# Altium schematic sheet SoM.SchDoc(SoM)
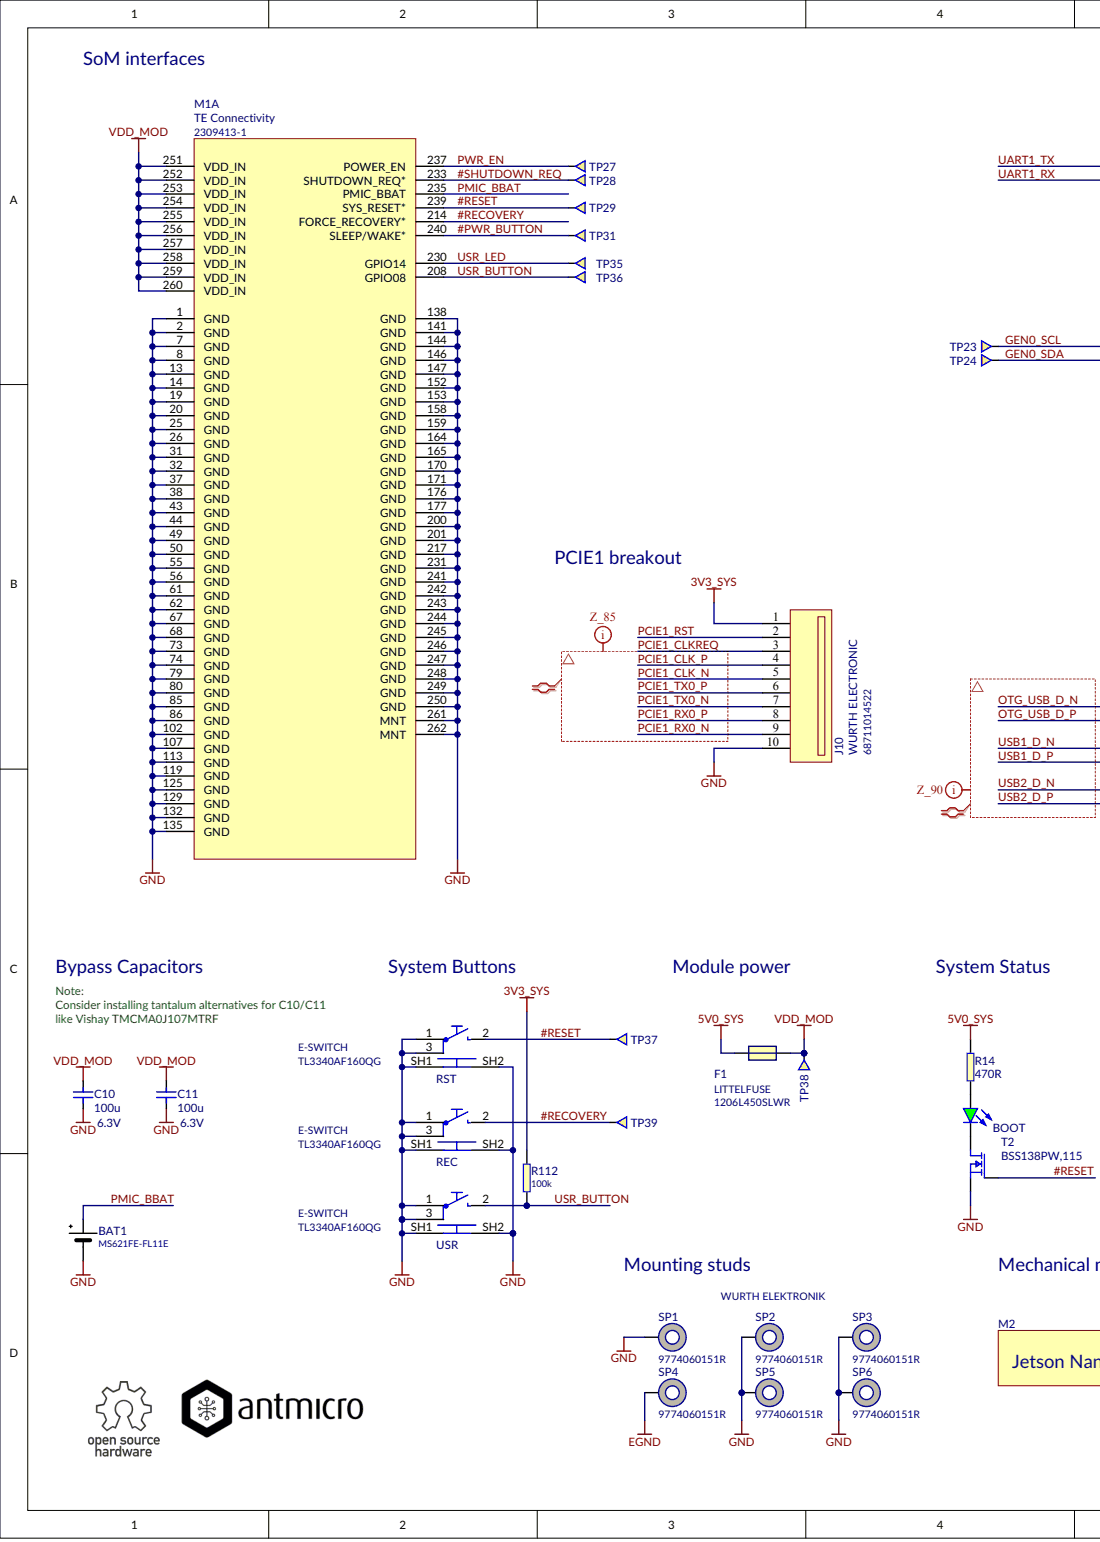
[diagram p0: SoM.SchDoc, left]
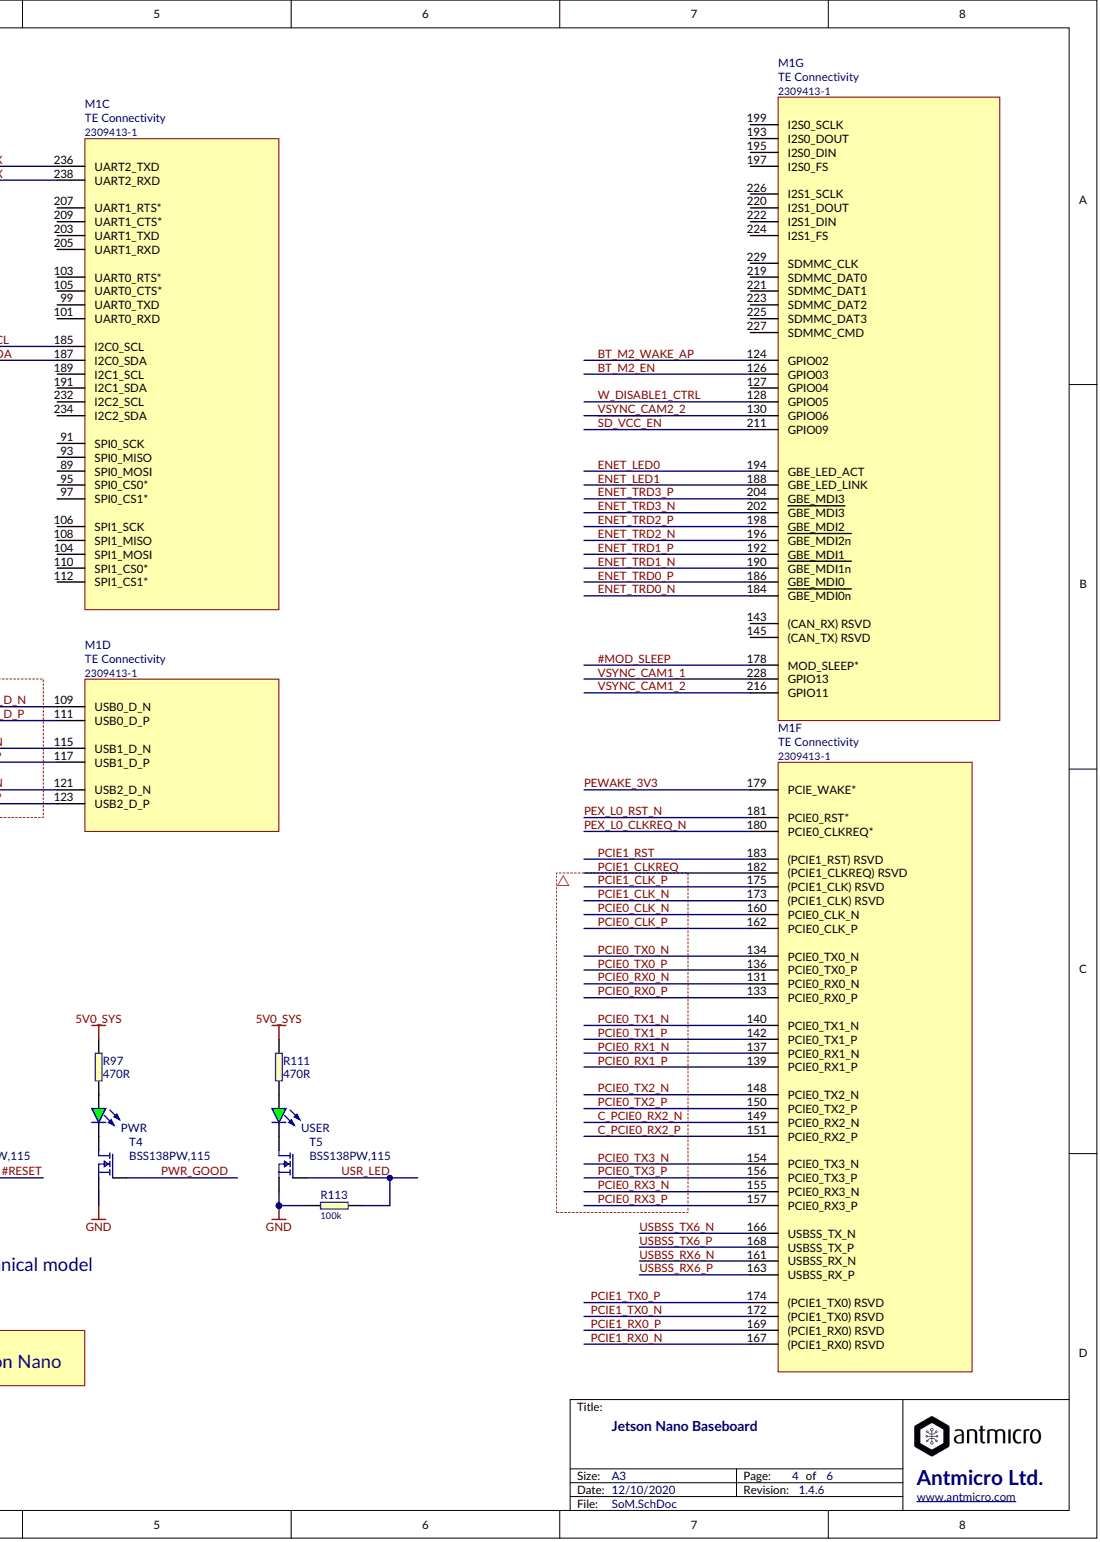
[diagram p1: SoM.SchDoc, right]

## Parts
BAT1  MS621FE-FL11E
BOOT  APT1608SGC  (value not drawn)
C10  Voltage: 6.3V  (hidden comment: 100u)
C11  Voltage: 6.3V  (hidden comment: 100u)
F1  Manufacturer: LITTELFUSE  (hidden comment: Value)
J10  Manufacturer: WURTH ELECTRONIC  (hidden comment: 68711014522)
M1  Manufacturer: TE Connectivity  (hidden comment: 2309413-1)  (parts A,C,D,F,G)
M2  =Value
PWR  APT1608SGC  (value not drawn)
R14  470R
R97  470R
R111  470R
R112  100k
R113  100k
REC  TL3340AF160QG
RST  TL3340AF160QG
SP1  MPN: 9774060151R  (hidden comment: 9774060151)
SP2  Manufacturer: WURTH ELEKTRONIK  MPN: 9774060151R  (hidden comment: 9774060151)
SP3  MPN: 9774060151R  (hidden comment: 9774060151)
SP4  MPN: 9774060151R  (hidden comment: 9774060151)
SP5  MPN: 9774060151R  (hidden comment: 9774060151)
SP6  MPN: 9774060151R  (hidden comment: 9774060151)
T2  BSS138PW,115
T4  BSS138PW,115
T5  BSS138PW,115
TP23  Testpad  (value not drawn)
TP24  Testpad  (value not drawn)
TP27  Testpad  (value not drawn)
TP28  Testpad  (value not drawn)
TP29  Testpad  (value not drawn)
TP31  Testpad  (value not drawn)
TP35  Testpad  (value not drawn)
TP36  Testpad  (value not drawn)
TP37  Testpad  (value not drawn)
TP38  Testpad  (value not drawn)
TP39  Testpad  (value not drawn)
USER  APT1608SGC  (value not drawn)
USR  TL3340AF160QG

## Nets
3V3_SYS: J10.1 R112.2
5V0_SYS: F1.1 R14.2 R97.2 R111.2
EGND: SP4.1
GND: BAT1.- C10.1 C11.1 J10.10 M1.1(GND) M1.2(GND) M1.7(GND) M1.8(GND) M1.13(GND) M1.14(GND) M1.19(GND) M1.20(GND) M1.25(GND) M1.26(GND) M1.31(GND) M1.32(GND) M1.37(GND) M1.38(GND) M1.43(GND) M1.44(GND) M1.49(GND) M1.50(GND) M1.55(GND) M1.56(GND) M1.61(GND) M1.62(GND) M1.67(GND) M1.68(GND) M1.73(GND) M1.74(GND) M1.79(GND) M1.80(GND) M1.85(GND) M1.86(GND) M1.102(GND) M1.107(GND) M1.113(GND) M1.119(GND) M1.125(GND) M1.129(GND) M1.132(GND) M1.135(GND) M1.138(GND) M1.141(GND) M1.144(GND) M1.146(GND) M1.147(GND) M1.152(GND) M1.153(GND) M1.158(GND) M1.159(GND) M1.164(GND) M1.165(GND) M1.170(GND) M1.171(GND) M1.176(GND) M1.177(GND) M1.200(GND) M1.201(GND) M1.217(GND) M1.231(GND) M1.241(GND) M1.242(GND) M1.243(GND) M1.244(GND) M1.245(GND) M1.246(GND) M1.247(GND) M1.248(GND) M1.249(GND) M1.250(GND) M1.261(MNT) M1.262(MNT) R113.2 REC.1 REC.3 REC.SH1 REC.SH2 RST.1 RST.3 RST.SH1 RST.SH2 SP1.1 SP2.1 SP3.1 SP5.1 SP6.1 T2.2 T4.2 T5.2 USR.1 USR.3 USR.SH1 USR.SH2
VDD_MOD: C10.2 C11.2 F1.2 M1.251(VDD_IN) M1.252(VDD_IN) M1.253(VDD_IN) M1.254(VDD_IN) M1.255(VDD_IN) M1.256(VDD_IN) M1.257(VDD_IN) M1.258(VDD_IN) M1.259(VDD_IN) M1.260(VDD_IN) TP38.1
#MOD_SLEEP: M1.178(MOD_SLEEP*)
#PWR_BUTTON: M1.240(SLEEP/WAKE*) TP31.1
#RECOVERY: M1.214(FORCE_RECOVERY*) REC.2 TP39.1
#RESET: M1.239(SYS_RESET*) RST.2 T2.1 TP29.1 TP37.1
#SHUTDOWN_REQ: M1.233(SHUTDOWN_REQ*) TP28.1
~{PEWAKE_3V3}: M1.179(PCIE_WAKE*)
BT_M2_EN: M1.126(GPIO03)
BT_M2_WAKE_AP: M1.124(GPIO02)
ENET_LED0: M1.194(GBE_LED_ACT)
ENET_LED1: M1.188(GBE_LED_LINK)
ENET_TRD0_N: M1.184(GBE_MDI0n)
ENET_TRD0_P: M1.186(GBE_MDI0)
ENET_TRD1_N: M1.190(GBE_MDI1n)
ENET_TRD1_P: M1.192(GBE_MDI1)
ENET_TRD2_N: M1.196(GBE_MDI2n)
ENET_TRD2_P: M1.198(GBE_MDI2)
ENET_TRD3_N: M1.202(GBE_MDI3)
ENET_TRD3_P: M1.204(GBE_MDI3)
GEN0_SCL: M1.185(I2C0_SCL) TP23.1
GEN0_SDA: M1.187(I2C0_SDA) TP24.1
OTG_USB_D_N: M1.109(USB0_D_N)
OTG_USB_D_P: M1.111(USB0_D_P)
PCIE0_CLK_N: M1.160(PCIE0_CLK_N)
PCIE0_CLK_P: M1.162(PCIE0_CLK_P)
PCIE0_RX0_N: M1.131(PCIE0_RX0_N)
PCIE0_RX0_P: M1.133(PCIE0_RX0_P)
PCIE0_RX1_N: M1.137(PCIE0_RX1_N)
PCIE0_RX1_P: M1.139(PCIE0_RX1_P)
PCIE0_RX2_N: M1.149(PCIE0_RX2_N)
PCIE0_RX2_P: M1.151(PCIE0_RX2_P)
PCIE0_RX3_N: M1.155(PCIE0_RX3_N)
PCIE0_RX3_P: M1.157(PCIE0_RX3_P)
PCIE0_TX0_N: M1.134(PCIE0_TX0_N)
PCIE0_TX0_P: M1.136(PCIE0_TX0_P)
PCIE0_TX1_N: M1.140(PCIE0_TX1_N)
PCIE0_TX1_P: M1.142(PCIE0_TX1_P)
PCIE0_TX2_N: M1.148(PCIE0_TX2_N)
PCIE0_TX2_P: M1.150(PCIE0_TX2_P)
PCIE0_TX3_N: M1.154(PCIE0_TX3_N)
PCIE0_TX3_P: M1.156(PCIE0_TX3_P)
PCIE1_CLK_N: J10.5 M1.173((PCIE1_CLK)_RSVD)
PCIE1_CLK_P: J10.4 M1.175((PCIE1_CLK)_RSVD)
PCIE1_CLKREQ: J10.3 M1.182((PCIE1_CLKREQ)_RSVD)
PCIE1_RST: J10.2 M1.183((PCIE1_RST)_RSVD)
PCIE1_RX0_N: J10.9 M1.167((PCIE1_RX0)_RSVD)
PCIE1_RX0_P: J10.8 M1.169((PCIE1_RX0)_RSVD)
PCIE1_TX0_N: J10.7 M1.172((PCIE1_TX0)_RSVD)
PCIE1_TX0_P: J10.6 M1.174((PCIE1_TX0)_RSVD)
PEX_L0_CLKREQ_N: M1.180(PCIE0_CLKREQ*)
PEX_L0_RST_N: M1.181(PCIE0_RST*)
PMIC_BBAT: BAT1.+ M1.235(PMIC_BBAT)
PWR_EN: M1.237(POWER_EN) TP27.1
PWR_GOOD: T4.1
~{SD_VCC_EN}: M1.211(GPIO09)
UART1_RX: M1.238(UART2_RXD)
UART1_TX: M1.236(UART2_TXD)
USB1_D_N: M1.115(USB1_D_N)
USB1_D_P: M1.117(USB1_D_P)
USB2_D_N: M1.121(USB2_D_N)
USB2_D_P: M1.123(USB2_D_P)
USBSS_RX6_N: M1.161(USBSS_RX_N)
USBSS_RX6_P: M1.163(USBSS_RX_P)
USBSS_TX6_N: M1.166(USBSS_TX_N)
USBSS_TX6_P: M1.168(USBSS_TX_P)
USR_BUTTON: M1.208(GPIO08) R112.1 TP36.1 USR.2
USR_LED: M1.230(GPIO14) R113.1 T5.1 TP35.1
VSYNC_CAM1_1: M1.228(GPIO13)
VSYNC_CAM1_2: M1.216(GPIO11)
VSYNC_CAM2_2: M1.130(GPIO06)
W_DISABLE1_CTRL: M1.128(GPIO05)
NetBOOT_A: BOOT.A R14.1
NetBOOT_K: BOOT.K T2.3
NetPWR_A: PWR.A R97.1
NetPWR_K: PWR.K T4.3
NetR111_1: R111.1 USER.A
NetT5_3: T5.3 USER.K
N00362:
N00363:
N00364:
N00365:

Not connected: M1 89(SPI0_MOSI) 91(SPI0_SCK) 93(SPI0_MISO) 95(SPI0_CS0*) 97(SPI0_CS1*) 99(UART0_TXD) 101(UART0_RXD) 103(UART0_RTS*) 104(SPI1_MOSI) 105(UART0_CTS*) 106(SPI1_SCK) 108(SPI1_MISO) 110(SPI1_CS0*) 112(SPI1_CS1*) 127(GPIO04) 143((CAN_RX)_RSVD) 145((CAN_TX)_RSVD) 189(I2C1_SCL) 191(I2C1_SDA) 193(I2S0_DOUT) 195(I2S0_DIN) 197(I2S0_FS) 199(I2S0_SCLK) 203(UART1_TXD) 205(UART1_RXD) 207(UART1_RTS*) 209(UART1_CTS*) 219(SDMMC_DAT0) 220(I2S1_DOUT) 221(SDMMC_DAT1) 222(I2S1_DIN) 223(SDMMC_DAT2) 224(I2S1_FS) 225(SDMMC_DAT3) 226(I2S1_SCLK) 227(SDMMC_CMD) 229(SDMMC_CLK) 232(I2C2_SCL) 234(I2C2_SDA)

## Library data of the parts
BAT1 = (no symbol name): Manufacturer: SEIKO INSTRUMENTS; PartNumber: MS621FE-FL11E; Value: MS621FE-FL11E; MPN: MS621FE-FL11E
BOOT PWR USER = (no symbol name): PartNumber: LED_G; Manufacturer: KINGBRIGHT; Value: APT1608SGC; MPN: APT1608SGC
C10 C11 = (no symbol name): PartNumber: C_100u_1206; Manufacturer: KEMET; MPN: C1206C107M9PACTU; Value: 100u
F1 = (no symbol name): PartNumber: LITTELFUSE_1206L450SLWR; Value: 1206L450SLWR; MPN: 1206L450SLWR
M2 = (no symbol name): PartNumber: jetson-nano-module
R14 R97 R111 = (no symbol name): PartNumber: R_470R_0402; Manufacturer: VISHAY; MPN: CRCW0402470RFKEDC; Value: 470R
R112 R113 = (no symbol name): PartNumber: R_100k_0402; Manufacturer: VISHAY; MPN: CRCW0402100KFKEDHP; Value: 100k
REC RST USR = (no symbol name): Manufacturer: E-SWITCH; Value: TL3340AF160QG; PartNumber: TL3340AF160QG; MPN: TL3340AF160QG
SP1 SP3-SP6 = (no symbol name): PartNumber: M2.5x6; Manufacturer: WURTH ELEKTRONIK; Value: 9774060151
SP2 = (no symbol name): PartNumber: M2.5x6; Value: 9774060151
T2 T4 T5 = (no symbol name): Manufacturer: NXP; Value: BSS138PW,115; PartNumber: BSS138PW,115; MPN: BSS138PW,115
TP23 TP24 TP27-TP29 TP31 TP35-TP39 = (no symbol name): PartNumber: TP-R-1.5
J10 M1 = (no symbol name)
  J10: PartNumber: 68711014522; Value: 68711014522; MPN: 68711014522
  M1: PartNumber: 2309413-1; Value: 2309413-1; MPN: 2309413-1
